# Altium schematic sheet [26] - PWR I.SchDoc("[26] - PWR I")
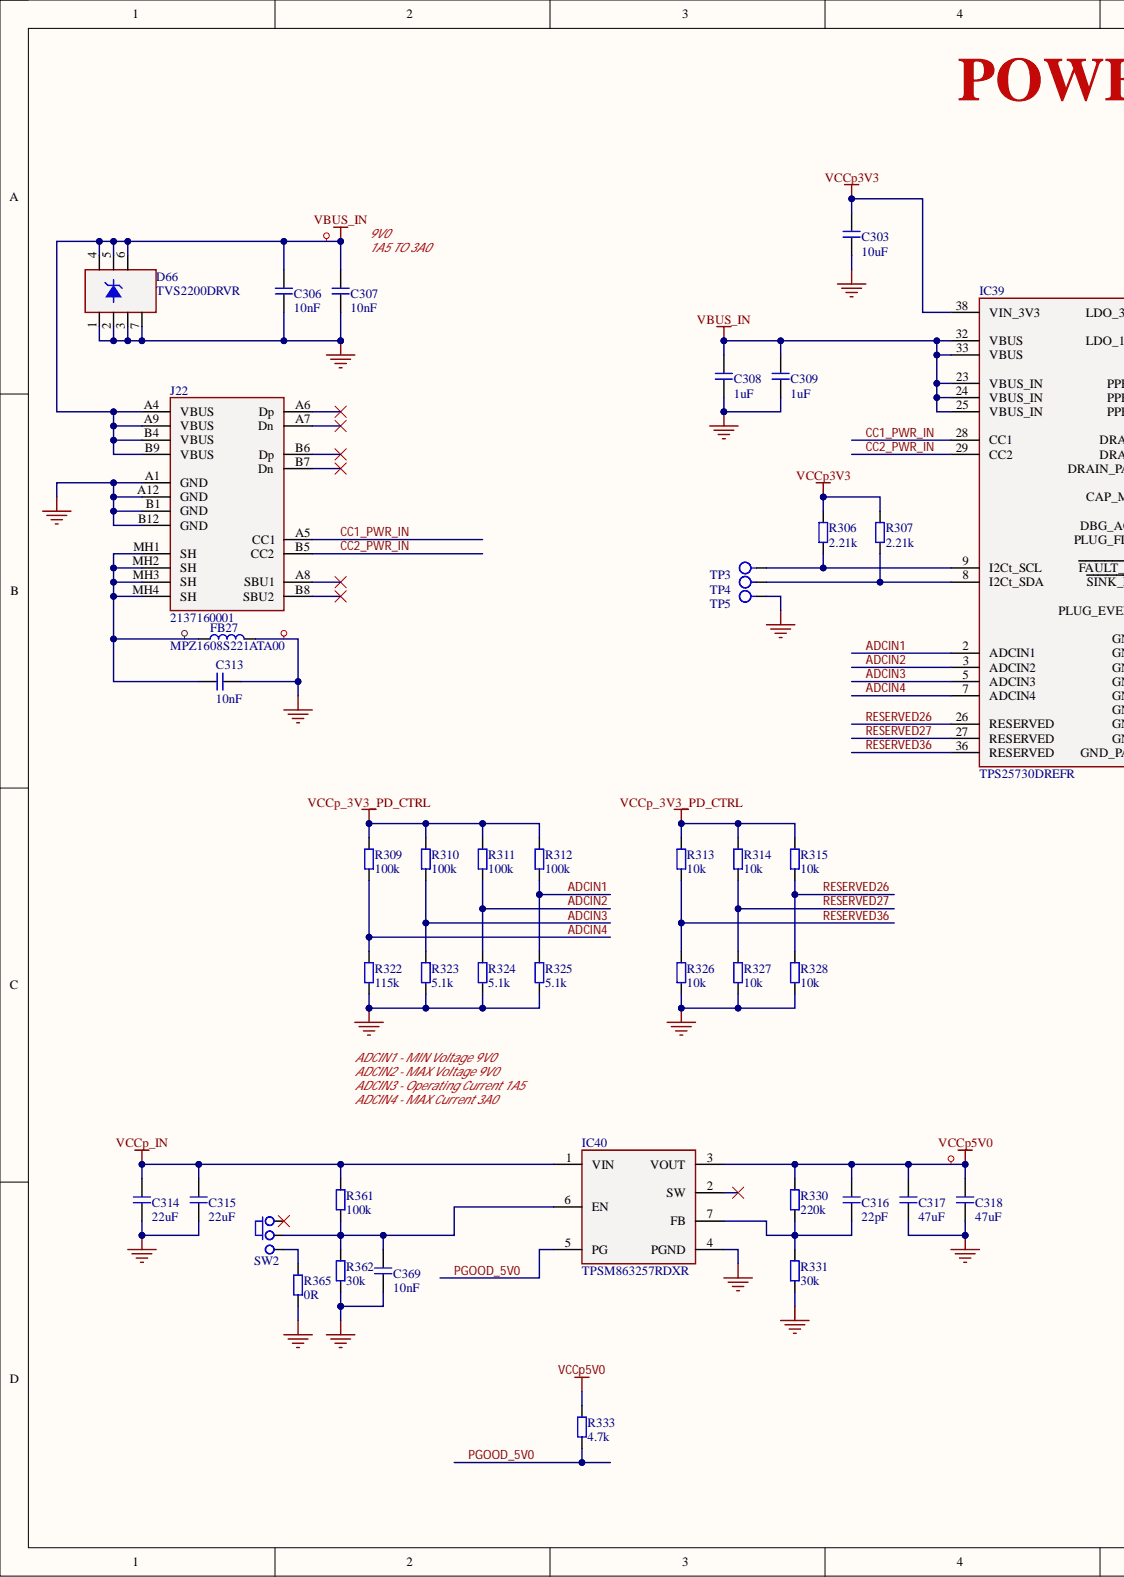
[diagram p0: [26] - PWR I.SchDoc, left]
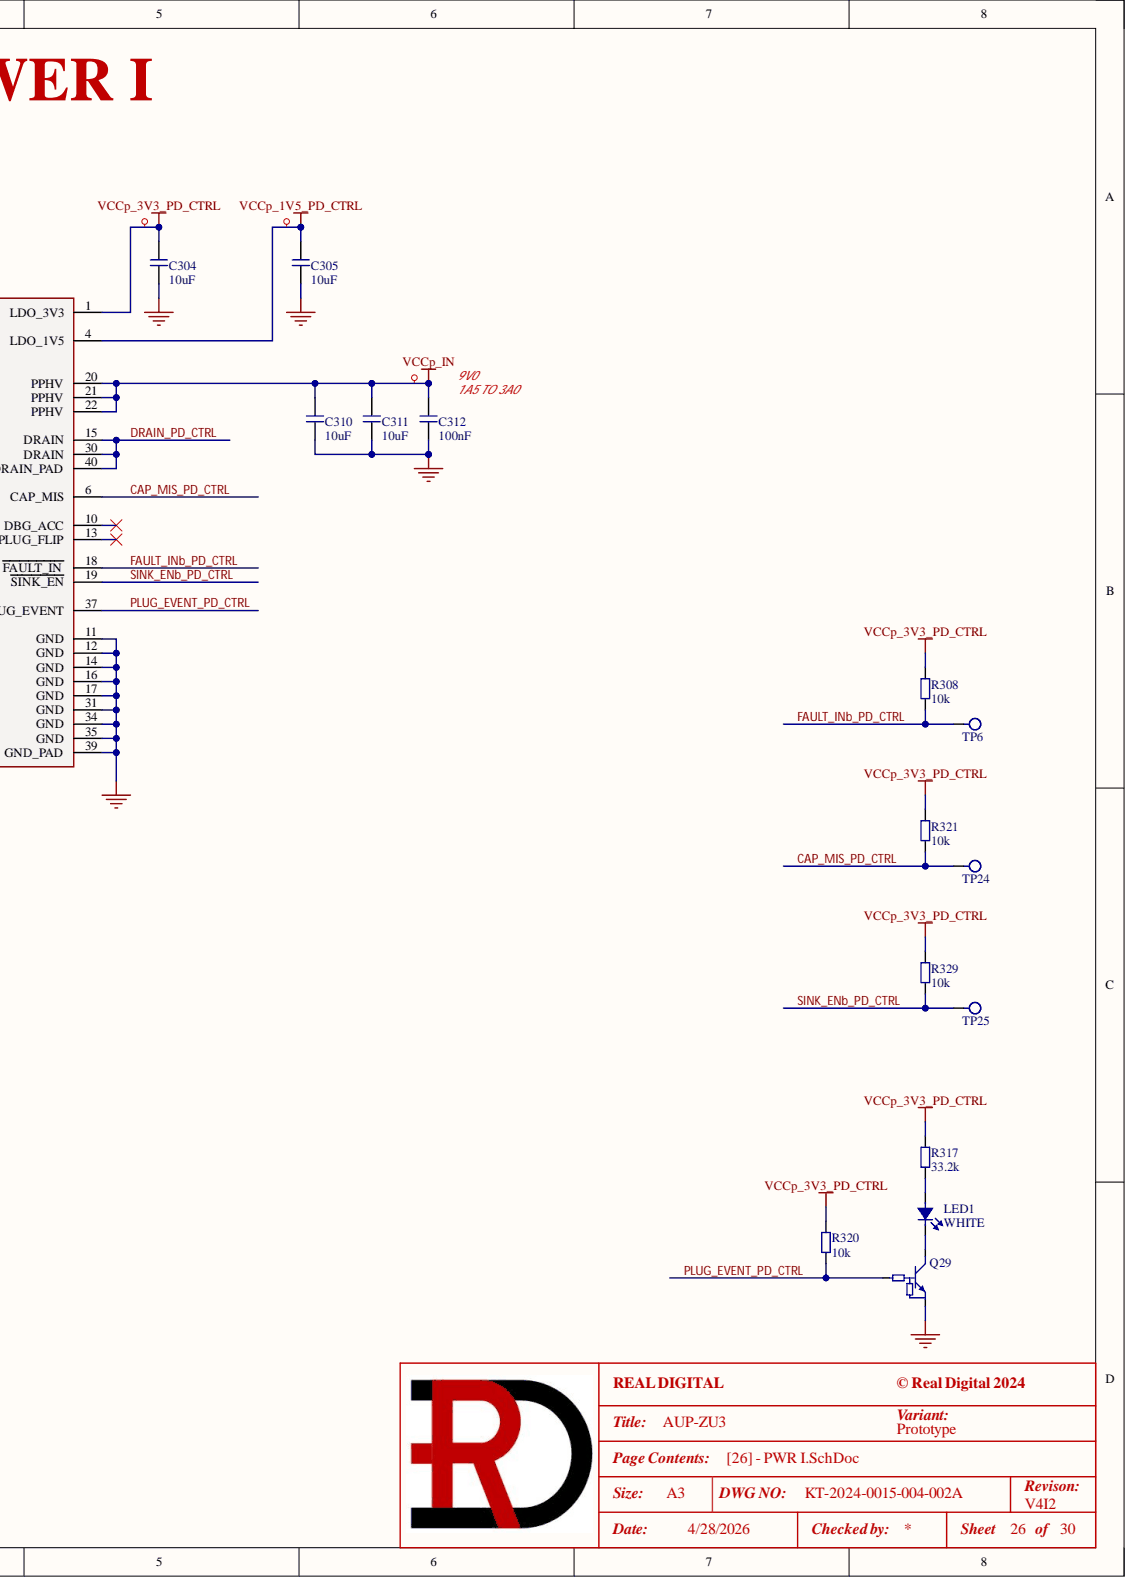
[diagram p1: [26] - PWR I.SchDoc, right]

## Parts
C303  10uF  [C0603]
C304  10uF  [C0603]
C305  10uF  [C0603]
C306  10nF  [C0402]
C307  10nF  [C0402]
C308  1uF  [C0603]
C309  1uF  [C0603]
C310  10uF  [C0603]
C311  10uF  [C0603]
C312  100nF  [C0402]
C313  10nF  [C0402]
C314  22uF  [C0805]
C315  22uF  [C0805]
C316  22pF  [C0402]
C317  47uF  [C1210]
C318  47uF  [C1210]
C369  10nF  [C0402]
D66  TVS2200DRVR  [DRV0006A_WSON_6 - TI]
FB27  MPZ1608S221ATA00  [MPZ1608]
IC39  TPS25730DREFR  [REF0038A - TI]
IC40  TPSM863257RDXR  [RDX0007A - TI]
J22  2137160001  [2137160001]
LED1  WHITE  [LD0603_White]
Q29  DTC043ZEBTL  [SC89-EMT3F]  (value not drawn)
R306  2.21k  [R0402]
R307  2.21k  [R0402]
R308  10k  [R0402]
R309  100k  [R0402]
R310  100k  [R0402]
R311  100k  [R0402]
R312  100k  [R0402]
R313  10k  [R0402]
R314  10k  [R0402]
R315  10k  [R0402]
R317  33.2k  [R0402]
R320  10k  [R0402]
R321  10k  [R0402]
R322  115k  [R0402]
R323  5.1k  [R0402]
R324  5.1k  [R0402]
R325  5.1k  [R0402]
R326  10k  [R0402]
R327  10k  [R0402]
R328  10k  [R0402]
R329  10k  [R0402]
R330  220k  [R0402]
R331  30k  [R0402]
R333  4.7k  [R0402]
R361  100k  [R0402]
R362  30k  [R0402]
R365  0R  [R0402]
SW2  Switch  [SW-SS12F20G4-10.5-HA-G]  (value not drawn)
TP3  1mm  [TP_1MM]  (value not drawn)  (no BOM)
TP4  1mm  [TP_1MM]  (value not drawn)  (no BOM)
TP5  1mm  [TP_1MM]  (value not drawn)  (no BOM)
TP6  1mm  [TP_1MM]  (value not drawn)  (no BOM)
TP24  1mm  [TP_1MM]  (value not drawn)  (no BOM)
TP25  1mm  [TP_1MM]  (value not drawn)  (no BOM)

## Nets
GND: C303.1 C304.1 C305.1 C306.1 C307.1 C308.1 C309.1 C310.1 C311.1 C312.1 C313.2 C314.1 C315.1 C317.1 C318.1 C369.1 D66.1 D66.2 D66.3 D66.7 FB27.2 IC39.11(GND) IC39.12(GND) IC39.14(GND) IC39.16(GND) IC39.17(GND) IC39.31(GND) IC39.34(GND) IC39.35(GND) IC39.39(GND_PAD) IC40.4(PGND) J22.A1(GND) J22.A12(GND) J22.B1(GND) J22.B12(GND) Q29.GND R322.1 R323.1 R324.1 R325.1 R326.1 R327.1 R328.1 R331.1 R362.1 R365.1 TP5.1
VBUS_IN: C306.2 C307.2 C308.2 C309.2 D66.4 D66.5 D66.6 IC39.23(VBUS_IN) IC39.24(VBUS_IN) IC39.25(VBUS_IN) IC39.32(VBUS) IC39.33(VBUS) J22.A4(VBUS) J22.A9(VBUS) J22.B4(VBUS) J22.B9(VBUS)
VCCp3V3: C303.2 IC39.38(VIN_3V3) R306.2 R307.2
VCCp5V0: C316.2 C317.2 C318.2 IC40.3(VOUT) R330.2 R333.2
VCCp_1V5_PD_CTRL: C305.2 IC39.4(LDO_1V5)
VCCp_3V3_PD_CTRL: C304.2 IC39.1(LDO_3V3) R308.2 R309.2 R310.2 R311.2 R312.2 R313.2 R314.2 R315.2 R317.2 R320.2 R321.2 R329.2
VCCp_IN: C310.2 C311.2 C312.2 C314.2 C315.2 IC39.20(PPHV) IC39.21(PPHV) IC39.22(PPHV) IC40.1(VIN) R361.2
ADCIN1: IC39.2(ADCIN1) R312.1 R325.2
ADCIN2: IC39.3(ADCIN2) R311.1 R324.2
ADCIN3: IC39.5(ADCIN3) R310.1 R323.2
ADCIN4: IC39.7(ADCIN4) R309.1 R322.2
CAP_MIS_PD_CTRL: IC39.6(CAP_MIS) R321.1 TP24.1
CC1_PWR_IN: IC39.28(CC1) J22.A5(CC1)
CC2_PWR_IN: IC39.29(CC2) J22.B5(CC2)
DRAIN_PD_CTRL: IC39.15(DRAIN) IC39.30(DRAIN) IC39.40(DRAIN_PAD)
FAULT_INb_PD_CTRL: IC39.18(FAULT_IN) R308.1 TP6.1
PGOOD_5V0: IC40.5(PG) R333.1
PLUG_EVENT_PD_CTRL: IC39.37(PLUG_EVENT) Q29.IN R320.1
RESERVED26: IC39.26(RESERVED) R315.1 R328.2
RESERVED27: IC39.27(RESERVED) R314.1 R327.2
RESERVED36: IC39.36(RESERVED) R313.1 R326.2
SINK_ENb_PD_CTRL: IC39.19(SINK_EN) R329.1 TP25.1
NetC313_1: C313.1 FB27.1 J22.MH1(SH) J22.MH2(SH) J22.MH3(SH) J22.MH4(SH)
NetC316_1: C316.1 IC40.7(FB) R330.1 R331.2
NetC369_2: C369.2 IC40.6(EN) R361.1 R362.2 SW2.2
NetIC39_8: IC39.8(I2Ct_SDA) R307.1 TP4.1
NetIC39_9: IC39.9(I2Ct_SCL) R306.1 TP3.1
NetLED1_A: LED1.A R317.1
NetLED1_K: LED1.K Q29.OUT
NetR365_2: R365.2 SW2.3

Not connected: IC39 10(DBG_ACC) 13(PLUG_FLIP); IC40 2(SW); J22 A6(Dp) A7(Dn) A8(SBU1) B6(Dp) B7(Dn) B8(SBU2); SW2 1

## Library data of the parts
C303-C305 C310 C311 = GRM188R6YA106MA73D: CAP CER 10uF 35V X5R 0603; Manufacturer 1: Murata Electronics; .Checked_PCB: RV; C P/N: CY-111-0645; LoadStatus: Load; Tolerance: 20%; Category: Capacitors; Component Kind: Standard; Library Name: KT-RealDigital.IntLib; Manufacturer 1 Part Number: GRM188R6YA106MA73D; Temperature Coefficient: X5R; Voltage - Rated: 35V; .Checked_SCH: RV; .Creator: RV
C306 C307 C313 C369 = GRM155R71H103KA88J: CAP CER 10000pF 50V X7R 0402; Manufacturer 1: Murata Electronics; .Checked_PCB: AC; .Creator: RV; C P/N: RD-111-0003; LoadStatus: Load; Tolerance: 10%; Category: Capacitors; Component Kind: Standard; Library Name: KT-RealDigital.IntLib; Manufacturer 1 Part Number: GRM155R71H103KA88J; Temperature Coefficient: X7R; Voltage - Rated: 50V; .Checked_SCH: AC
C308 C309 = GRT188R61H105KE13D: CAP CER 1uF 50V X5R 0603; Manufacturer 1: Murata Electronics; .Checked_PCB: RV; C P/N: CY-111-0264; LoadStatus: Load; Tolerance: 10%; Category: Capacitors; Component Kind: Standard; Library Name: KT-RealDigital.IntLib; Manufacturer 1 Part Number: GRT188R61H105KE13D; Temperature Coefficient: X5R; Voltage - Rated: 50V; .Checked_SCH: RV; .Creator: RV
C312 = GRM155R71H104ME14J: CAP CER 0.1uF 50V X7R 0402; Manufacturer 1: Murata Electronics; .Checked_PCB: DG; .Creator: RV; C P/N: RD-111-0069; LoadStatus: Load; Tolerance: 20%; Category: Capacitors; Component Kind: Standard; Library Name: KT-RealDigital.IntLib; Manufacturer 1 Part Number: GRM155R71H104ME14J; Temperature Coefficient: X7R; Voltage - Rated: 50V; .Checked_SCH: DG
C314 C315 = GRM21BR61E226ME44K: CAP CER 22uF 25V X5R 0805; Manufacturer 1: Murata Electronics; .Checked_PCB: RV; C P/N: RD-111-0047; LoadStatus: Load; Tolerance: 20%; Category: Capacitors; Component Kind: Standard; Library Name: KT-RealDigital.IntLib; Manufacturer 1 Part Number: GRM21BR61E226ME44K; Temperature Coefficient: X5R; Voltage - Rated: 25V; .Checked_SCH: RV; .Creator: RV
C316 = GCM1555C1H220FA16D: CAP CER 22pF 50V C0G/NP0 0402; Manufacturer 1: Murata Electronics; .Checked_PCB: AC; .Creator: RV; C P/N: RD-111-0015; LoadStatus: Load; Temperature Coefficient: C0G, NP0; Tolerance: 1%; Category: Capacitors; Component Kind: Standard; Library Name: KT-RealDigital.IntLib; Manufacturer 1 Part Number: GCM1555C1H220FA16D; Voltage - Rated: 50V; .Checked_SCH: AC
C317 C318 = GRM32EC81C476KE15K: CAP CER 47uF 16V X6S 1210; Manufacturer 1: Murata Electronics; Manufacturer 2 Part Number: GRM32EC81C476KE15L; .Checked_PCB: AC; .Creator: RV; C P/N: RD-111-0007; LoadStatus: Load; Tolerance: 10%; Category: Capacitors; Component Kind: Standard; Library Name: KT-RealDigital.IntLib; Manufacturer 1 Part Number: GRM32EC81C476KE15K; Manufacturer 2: Murata Electronics; Temperature Coefficient: X6S; Voltage - Rated: 16V; .Checked_SCH: AC
D66 = TVS2200DRVR: UNIDIR PRECISION SURGE DIODE; Manufacturer 1: Texas Instruments; .Checked_PCB: RV; C P/N: RD-121-0013; LoadStatus: Load; Category: TVS - Diodes; Component Kind: Standard; Library Name: KT-RealDigital.IntLib; Manufacturer 1 Part Number: TVS2200DRVR; .Checked_SCH: RV; .Creator: RV
FB27 = MPZ1608S221ATA00: FERRITE BEAD 220 OHM 0603 1LN; Manufacturer 1: TDK Corporation; .Checked_PCB: AC; .Checked_SCH: RV; C P/N: CY-121-0068; LoadStatus: Load; Category: Ferrite Beads; Component Kind: Standard; Library Name: KT-RealDigital.IntLib; Manufacturer 1 Part Number: MPZ1608S221ATA00; .Creator: RV
IC39 = TPS25730DREFR: USB Type-C and USB PD Controller with Integrated Power Switches  Optimized for Power Applications; Manufacturer 1: Texas Instruments; .Checked_PCB: RV; C P/N: RD-126-1524; LoadStatus: Load; Category: IC; Component Kind: Standard; Library Name: KT-RealDigital.IntLib; Manufacturer 1 Part Number: TPS25730DREFR; .Checked_SCH: RV; .Creator: RV
IC40 = TPSM863257RDXR: 3V to 17V Input, 3A, Synchronous Buck Module in QFN Package; Manufacturer 1: Texas Instruments; .Checked_PCB: RV; C P/N: RD-126-1525; LoadStatus: Load; Category: IC; Component Kind: Standard; Library Name: KT-RealDigital.IntLib; Manufacturer 1 Part Number: TPSM863257RDXR; .Checked_SCH: RV; .Creator: RV
J22 = 2137160001: CONN RCPT USB2.0 TYPE C 24POS RA; Manufacturer 1: Molex; .Checked_PCB: RV; C P/N: RD-163-0020; LoadStatus: Load; Category: Connectors; Component Kind: Standard; KT P/N: RD-163-0020; Library Name: KT-RealDigital.IntLib; Manufacturer 1 Part Number: 2137160001; .Checked_SCH: RV; .Creator: RV
LED1 = LED_WHITE: LED WHITE 0603; Manufacturer 1: Victory Electronics; Manufacturer 1 Part Number: VS 2WC7M.325; .Checked_PCB: AC; .Creator: RV; C P/N: RD-121-0010; KT P/N: MT-121-0002; LoadStatus: Load; Category: Led; Component Kind: Standard; Library Name: KT-RealDigital.IntLib; .Checked_SCH: AC
Q29 = DTC043ZEBTL: TRANS PREBIAS NPN 50V EMT3F; Manufacturer 1: Rohm Semiconductor; .Checked_PCB: RV; C P/N: RD-121-0006; LoadStatus: Load; Category: BJTs; Component Kind: Standard; Library Name: KT-RealDigital.IntLib; Manufacturer 1 Part Number: DTC043ZEBTL; .Checked_SCH: RV; .Creator: RV
R306 R307 = RC0402FR-072K21L: RES SMD 2.21K OHM 1% 1/16W 0402; Manufacturer 1: YAGEO; .Checked_PCB: RV; C P/N: RD-101-0019; LoadStatus: Load; Tolerance: 1%/TK100; Category: Resistors; Component Kind: Standard; Library Name: KT-RealDigital.IntLib; Manufacturer 1 Part Number: RC0402FR-072K21L; .Checked_SCH: RV; .Creator: RV
R308 R313-R315 R320 R321 R326-R329 = RC0402FR-0710KL: RES SMD 10K OHM 1% 1/16W 0402; Manufacturer 1: Yageo; .Checked_PCB: AC; .Creator: RV; C P/N: RD-101-0020; LoadStatus: Load; Tolerance: 1%/TK100; Category: Resistors; Component Kind: Standard; Library Name: KT-RealDigital.IntLib; Manufacturer 1 Part Number: RC0402FR-0710KL; .Checked_SCH: AC
R309-R312 = RT0402BRE07100KL: RES SMD 100K OHM 0.1% 1/16W 0402; Manufacturer 1: YAGEO; .Checked_PCB: RV; C P/N: RD-101-0081; LoadStatus: Load; Tolerance: 0.1%/TK50; Category: Resistors; Component Kind: Standard; Library Name: KT-RealDigital.IntLib; Manufacturer 1 Part Number: RT0402BRE07100KL; .Checked_SCH: RV; .Creator: RV
R317 = RC0402FR-0733K2L: RES 33.2K OHM 1% 1/16W 0402; Manufacturer 1: YAGEO; .Checked_PCB: RV; C P/N: 101-0566; LoadStatus: Load; Tolerance: 1%/TK100; Category: Resistors; Component Kind: Standard; Library Name: KT-RealDigital.IntLib; Manufacturer 1 Part Number: RC0402FR-0733K2L; .Checked_SCH: RV; .Creator: RV
R322 = RT0402BRE07115KL: RES SMD 115k OHM 0.1% 1/16W 0402; Manufacturer 1: YAGEO; .Checked_PCB: RV; C P/N: 101-1650; LoadStatus: Load; Tolerance: 0.1%/TK50; Category: Resistors; Component Kind: Standard; Library Name: KT-RealDigital.IntLib; Manufacturer 1 Part Number: RT0402BRE07115KL; .Checked_SCH: RV; .Creator: RV
R323-R325 = RT0402BRE075K1L: RES SMD 5.1K OHM 0.1% 1/16W 0402; Manufacturer 1: YAGEO; .Checked_PCB: RV; C P/N: 101-0764; LoadStatus: Load; Tolerance: 0.1%/TK50; Category: Resistors; Component Kind: Standard; Library Name: KT-RealDigital.IntLib; Manufacturer 1 Part Number: RT0402BRE075K1L; .Checked_SCH: RV; .Creator: RV
R330 = RT0402BRD07220KL: RES 220k OHM 0.1% 1/16W 0402; Manufacturer 1: YAGEO; .Checked_PCB: RV; C P/N: RD-101-0122; LoadStatus: Load; Tolerance: 0.1%/TK25; Category: Resistors; Component Kind: Standard; Library Name: KT-RealDigital.IntLib; Manufacturer 1 Part Number: RT0402BRD07220KL; .Checked_SCH: RV; .Creator: RV
R331 = RT0402BRE0730KL: RES SMD 30K OHM 0.1% 1/16W 0402; Manufacturer 1: YAGEO; .Checked_PCB: RV; C P/N: 101-1276; LoadStatus: Load; Tolerance: 0.1%/TK50; Category: Resistors; Component Kind: Standard; Library Name: KT-RealDigital.IntLib; Manufacturer 1 Part Number: RT0402BRE0730KL; .Checked_SCH: RV; .Creator: RV
R333 = RC0402FR-074K7P: RES SMD 4.7K OHM 1% 1/16W 0402; Manufacturer 1: Yageo; .Checked_PCB: AC; .Creator: RV; C P/N: 101-0129; LoadStatus: Load; Tolerance: 1%/TK100; Category: Resistors; Component Kind: Standard; Library Name: KT-RealDigital.IntLib; Manufacturer 1 Part Number: RC0402FR-074K7P; .Checked_SCH: AC
R361 = RC0402FR-07100KL: RES SMD 100K OHM 1% 1/16W 0402; Manufacturer 1: Yageo; .Checked_PCB: RV; C P/N: RD-101-0013; LoadStatus: Load; Tolerance: 1%/TK100; Category: Resistors; Component Kind: Standard; Library Name: KT-RealDigital.IntLib; Manufacturer 1 Part Number: RC0402FR-07100KL; .Checked_SCH: RV; .Creator: RV
R362 = RC0402FR-0730KL: RES 30K OHM 1% 1/16W 0402; Manufacturer 1: YAGEO; .Checked_PCB: RV; C P/N: 101-0088; LoadStatus: Load; Tolerance: 1%/TK100; Category: Resistors; Component Kind: Standard; Library Name: KT-RealDigital.IntLib; Manufacturer 1 Part Number: RC0402FR-0730KL; .Checked_SCH: RV; .Creator: RV
R365 = RC0402FR-070RL: RES SMD 0 OHM JUMPER 1/16W 0402; Manufacturer 1: YAGEO; .Checked_PCB: AC; .Creator: RV; C P/N: RD-101-0003; LoadStatus: Load; Category: Resistors; Component Kind: Standard; Library Name: KT-RealDigital.IntLib; Manufacturer 1 Part Number: RC0402FR-070RL; Tolerance: Jumper; .Checked_SCH: AC
SW2 = Slide_Switch_Long_Leads: Slide Switch Long Leads (7.35mm), SPDT, Thru Hole,; Manufacturer 1: SWITRONIC INDUSTRIAL CORP.; Manufacturer 1 Part Number: SS12F20G4-10.5-HA-G; .Checked_PCB: AC; .Creator: RV; C P/N: RD-153-0002; LoadStatus: Load; Category: Switches; Component Kind: Standard; Library Name: KT-RealDigital.IntLib; .Checked_SCH: AC
TP3-TP6 TP24 TP25 = TEST_POINT_1mm: TEST POINT round 1mm; Manufacturer 1: NA; .Checked_PCB: RV; LoadStatus: Load; Category: Test Point; Library Name: KT-RealDigital.IntLib; Manufacturer 1 Part Number: NA; Manufacturer 2: NA; Manufacturer 2 Part Number: NA; .Checked_SCH: RV; .Creator: RV
